# Altium schematic sheet SOC.SchDoc(SOC)
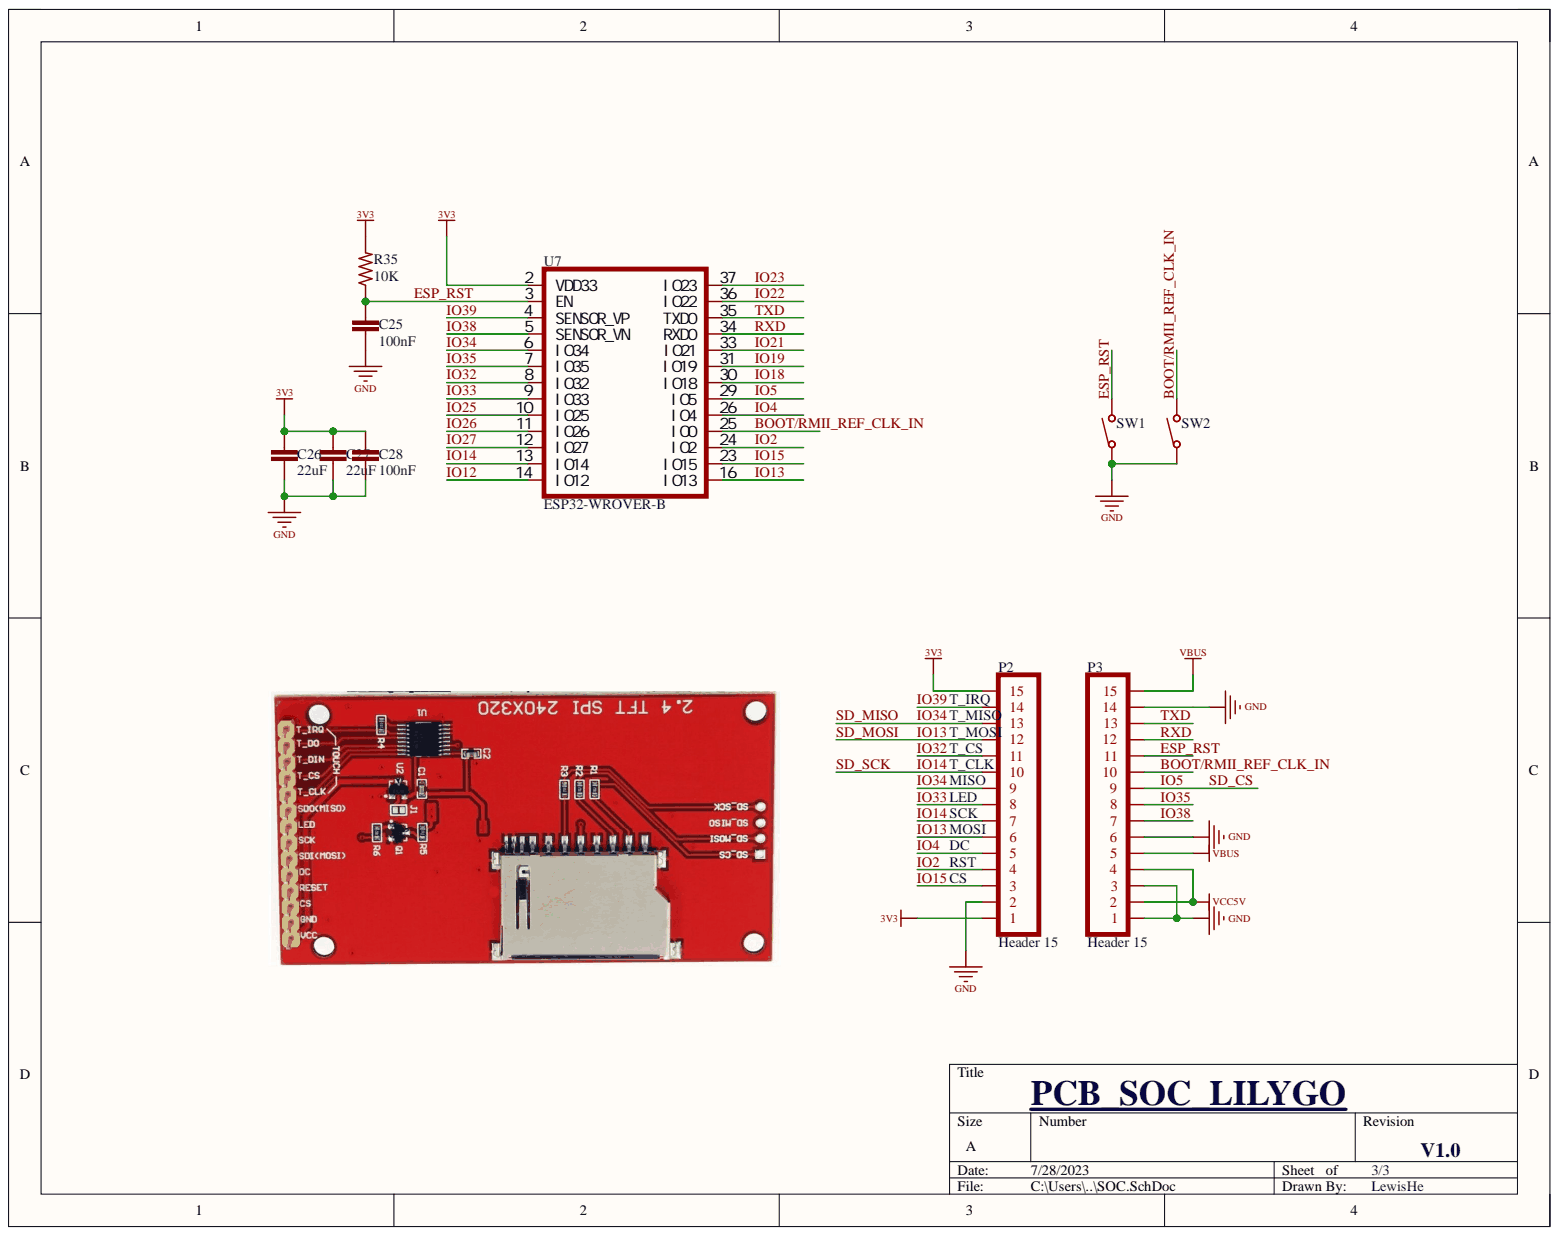
[diagram p0: SOC.SchDoc]

## Parts
C25  100nF  [C0402]
C26  22uF  [C0603]
C27  22uF  [C0603]
C28  100nF  [C0603]
P2  Header 15  [HDR1X15_插针]
P3  Header 15  [HDR1X15_插针]
R35  10K  [R0402]
SW1  TS342A2P-WZ  [SW-SMD_L4.0-W2.9-LS5.0]  (value not drawn)
SW2  TS342A2P-WZ  [SW-SMD_L4.0-W2.9-LS5.0]  (value not drawn)
U7  ESP32-WROVER-B  [WIFIM-SMD_39P-L31.4-W18.0-P1.27]

## Nets
3V3: C26.1 C27.1 C28.1 P2.1 P2.15 R35.2 U7.2(VDD33)
GND: C25.1 C26.2 C27.2 C28.2 P2.2 P3.1 P3.3 P3.6 P3.14 SW1.1 SW2.1 U7.1 U7.15 U7.38 U7.39
VBUS: P3.5 P3.15
VCC5V: P3.2 P3.4
BOOT/RMII_REF_CLK_IN: P3.10 SW2.2 U7.25(IO0)
ESP_RST: C25.2 P3.11 R35.1 SW1.2 U7.3(EN)
IO2: P2.4 U7.24(IO2)
IO4: P2.5 U7.26(IO4)
IO5: P3.9 U7.29(IO5)
IO12: U7.14(IO12)
IO13: P2.6 P2.12 U7.16(IO13)
IO14: P2.7 P2.10 U7.13(IO14)
IO15: P2.3 U7.23(IO15)
IO18: U7.30(IO18)
IO19: U7.31(IO19)
IO21: U7.33(IO21)
IO22: U7.36(IO22)
IO23: U7.37(IO23)
IO25: U7.10(IO25)
IO26: U7.11(IO26)
IO27: U7.12(IO27)
IO32: P2.11 U7.8(IO32)
IO33: P2.8 U7.9(IO33)
IO34: P2.9 P2.13 U7.6(IO34)
IO35: P3.8 U7.7(IO35)
IO38: P3.7 U7.5(SENSOR_VN)
IO39: P2.14 U7.4(SENSOR_VP)
RXD: P3.12 U7.34(RXD0)
TXD: P3.13 U7.35(TXD0)

## Library data of the parts
C25-C28 = C0201: 0201  贴片电容; Component Kind: Standard; Library Name: 常用.SCHLIB
P2 P3 = Header 15: 2.54mm 1x15 排针; Component Kind: Standard; Library Name: 常用.SCHLIB
R35 = R0201: Component Kind: Standard; Library Name: 常用.SCHLIB
SW1 SW2 = TS342A2P-WZ: 轻触开关 3*4*2 两脚小龟不带柱 250gf 黑色; Component Kind: Standard; Library Name: 常用.SCHLIB
U7 = ESP32-WROVER-B: Component Kind: Standard; Description: ESP32-WROVER-B; Library Name: 常用.SCHLIB
